# Altium schematic sheet Buck_Converter.SchDoc
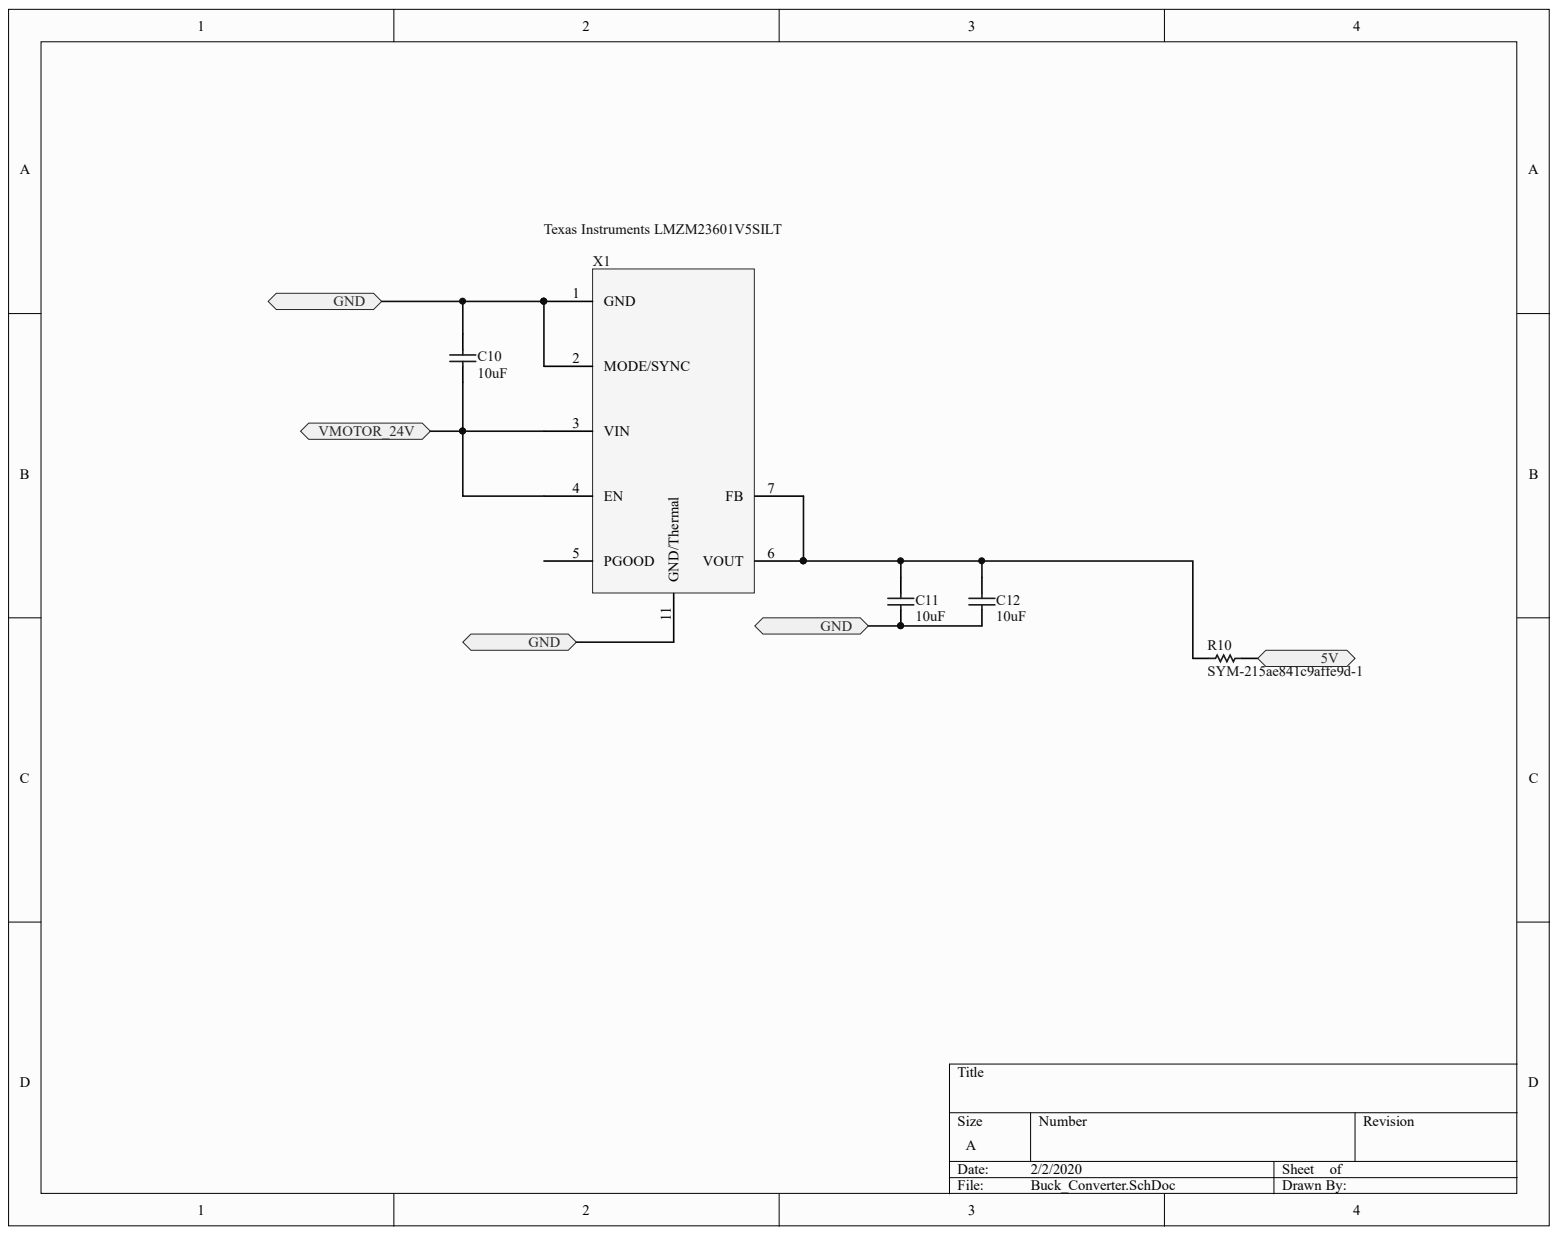
[diagram p0: Buck_Converter.SchDoc]

## Parts
C10  10uF  [PCB-fjsvh2tjaqovriebo4bq-1]
C11  10uF  [PCB-fjsvh2tjaqovriebo4bq-1]
C12  10uF  [PCB-fjsvh2tjaqovriebo4bq-1]
R10  SYM-215ae841c9affe9d-1  [PCB-c9ymia8zt8m1a3msw1jq-1]
X1  Texas Instruments LMZM23601V5SILT  [PCB-et9mmr8vzuf9nkg2ck12-1]

## Ports
5V, GND, VMOTOR_24V

## Nets
5V: R10.2 ; port 5V
GND: C10.1 C11.2 C12.2 X1.1(GND) X1.2(MODE/SYNC) X1.11(GND/Thermal) ; port GND
VMOTOR_24V: C10.2 X1.3(VIN) X1.4(EN) ; port VMOTOR_24V
NetC11_1: C11.1 C12.1 R10.1 X1.6(VOUT) X1.7(FB)

Not connected: X1 5(PGOOD)

## Library data of the parts
C10-C12 = CMP-84d84c8150e65b1f-1: Cap , mlcc , 1210, 50V , x5R, 10Uf+/-20% , t&r Rohs Compliant: Yes; Manufacturer Part Number: UMK325BJ106MM-P; Case/Package: 1210; Component Kind: Standard; Library Name: CommunityVault; Lifecycle Status: Not Listed by Manufacturer; Mounting Style: Surface Mount; OctopartID: 84d84c8150e65b1f; Octopart URL: https://octopart.com/umk325bj106mm-p-taiyo+yuden-22278488; Packaging: Tape & Reel (TR); RoHS: Compliant
R10 = CMP-1864855-3: Res Thin Film 0805 0.01 Ohm 5% 0.25W(1/4W) 0ppm/C to 350ppm/C Pad SMD Automotive T/R; Manufacturer Part Number: RL1220T-R010-J; Case/Package (SI): 2012; Power Rating: 250 mW; Case/Package: 0805; Component Kind: Standard; Library Name: CommunityVault; Mounting Style: Surface Mount; Operating Temperature: 155 °C (max); Size-Length: 2.00 mm
X1 = CMP-08fcc4b6f580d73b-3: 36-V, 1-A Step-Down DC-DC Power Module in 3.8-mm × 3-mm Package 10-uSiP -40 to 125; Component Kind: Standard; Library Name: CommunityVault
